# Altium schematic sheet SCH_Mayaptrung.SchDoc
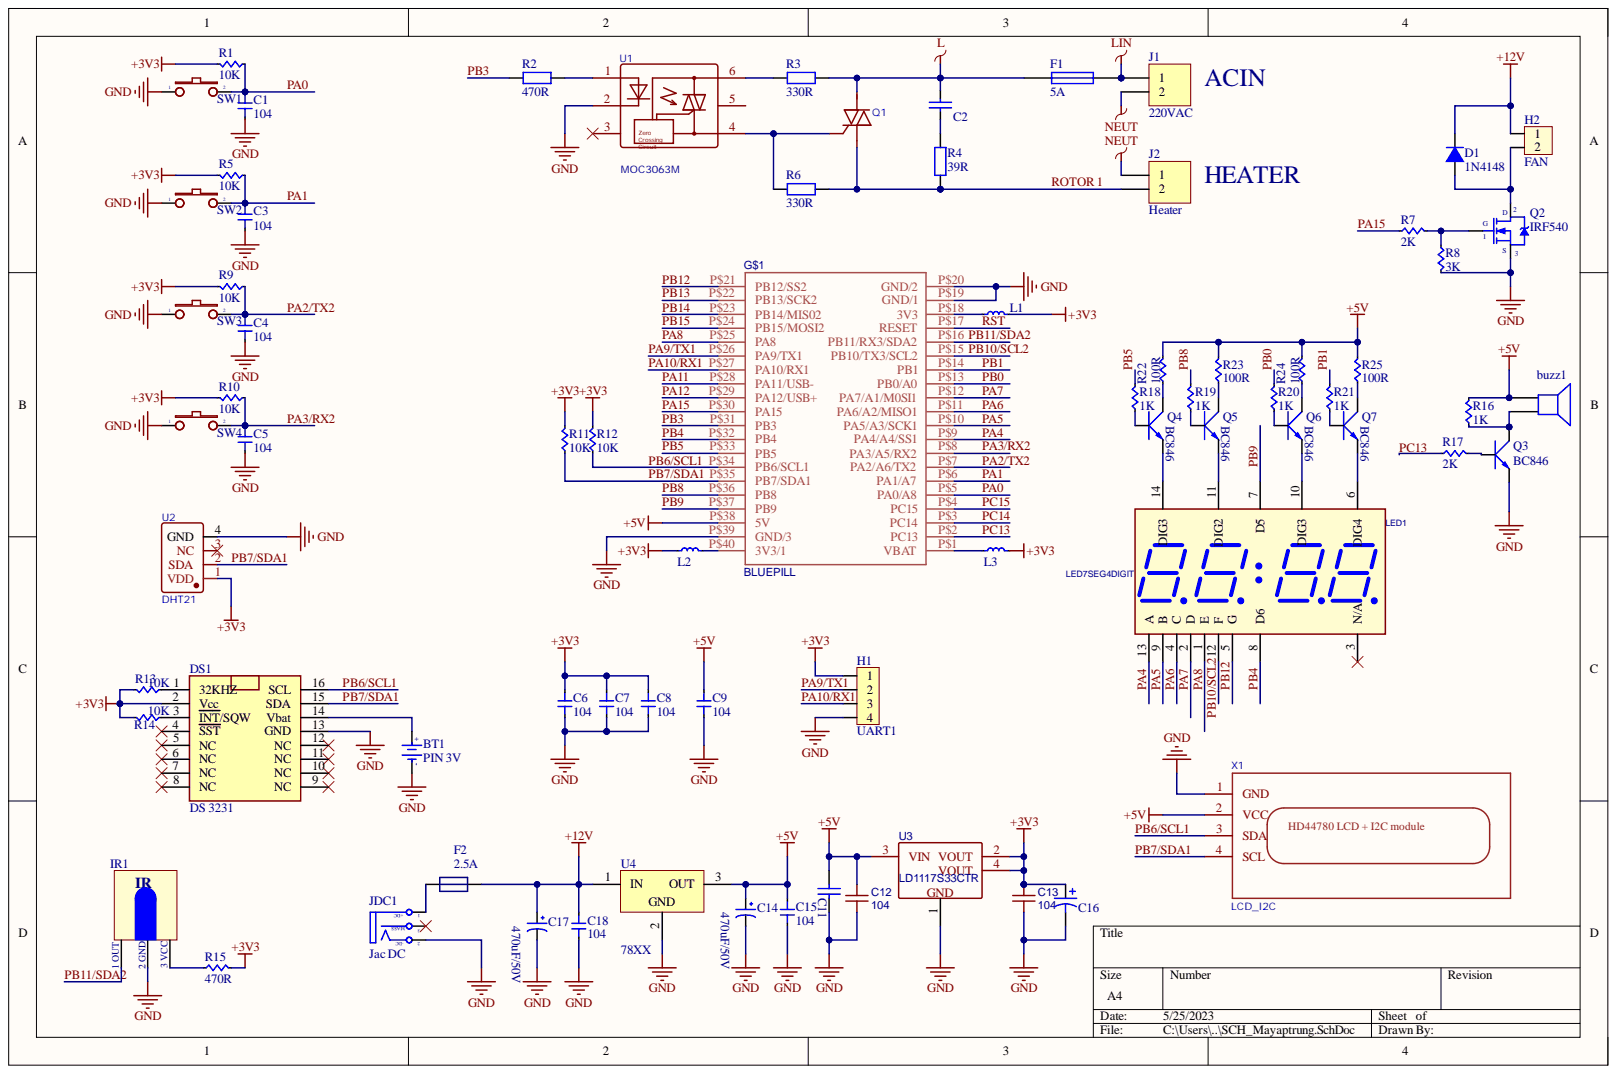
[diagram p0: SCH_Mayaptrung.SchDoc]

## Parts
BT1  PIN 3V  [PIN CR1220-SMD]
buzz1  Còi Chip 5V 9.5x12MM  [SPEAKER]  (value not drawn)
C1  104  [Cap 0805]
C2  104/630V  [TU FLIM P7.5 WIMA]  (value not drawn)
C3  104  [Cap 0805]
C4  104  [Cap 0805]
C5  104  [Cap 0805]
C6  104  [Cap 0805]
C7  104  [Cap 0805]
C8  104  [Cap 0805]
C9  104  [Cap 0805]
C11  100uF  [tu 1210 Dep]  (value not drawn)
C12  104  [Tu 0603]
C13  104  [Tu 0603]
C14  470uF/50V  [Tu hoa 8mm]
C15  104  [Cap 0805]
C16  470uF/16V  [CAP-SMD_BD6.3-L6.6-W6.6-FD]  (value not drawn)
C17  470uF/50V  [Tu hoa 8mm]
C18  104  [Cap 0805]
D1  1N4148  [Diode 1N4148]
DS1  DS 3231  [SO16L]
F1  5A  [Fuse15A]
F2  2.5A  [F1812]
G$1  BLUEPILL  [BLUEPILL]
H1  UART1  [XH4P-2.54mm]
H2  FAN  [Header_Duc Cong_2P 2.54 T]
IR1  IR1  [IR GP1UE26]
J1  220VAC  [KF3.81-2P-PL]
J2  Heater  [KF3.81-2P-PL]
JDC1  Jac DC  [DC-IN]
L1  LCF0603-1R8J-T  [L 0603]  (value not drawn)
L2  LCF0603-1R8J-T  [L 0603]  (value not drawn)
L3  LCF0603-1R8J-T  [L 0603]  (value not drawn)
LED1  LED7SEG4DIGIT  [LED 7 THANH  4 DIGIT 0.56]
Q1  BT136-800E_C2901010  [TO-252-3_L6.6-W6.1-P4.57-LS9.9-BR-CW]  (value not drawn)
Q2  IRF540  [TO220]
Q3  BC846  [SOT23BCE]
Q4  BC846  [SOT23BCE]
Q5  BC846  [SOT23BCE]
Q6  BC846  [SOT23BCE]
Q7  BC846  [SOT23BCE]
R1  10K  [Res 0805]
R2  470R  [tro smd 0805]
R3  330R  [tro smd 0805]
R4  39R  [tro smd 1206]
R5  10K  [Res 0805]
R6  330R  [tro smd 0805]
R7  2K  [Res 0805]
R8  3K  [Res 0805]
R9  10K  [Res 0805]
R10  10K  [Res 0805]
R11  10K  [Res 0805]
R12  10K  [Res 0805]
R13  10K  [Res 0805]
R14  10K  [Res 0805]
R15  470R  [Res 0805]
R16  1K  [Res 0805]
R17  2K  [Res 0805]
R18  1K  [Res 0805]
R19  1K  [Res 0805]
R20  1K  [Res 0805]
R21  1K  [Res 0805]
R22  100R  [Res 0805]
R23  100R  [Res 0805]
R24  100R  [Res 0805]
R25  100R  [Res 0805]
SW1  SW TACT 4PIN  [button 4.5x4.5x6 SMD]  (value not drawn)
SW2  SW TACT 4PIN  [button 4.5x4.5x6 SMD]  (value not drawn)
SW3  SW TACT 4PIN  [button 4.5x4.5x6 SMD]  (value not drawn)
SW4  SW TACT 4PIN  [button 4.5x4.5x6 SMD]  (value not drawn)
U1  MOC3063M  [DIP-6]
U2  DHT21  [SENSOR-TH_HAIGU_DHT21]
U3  LD1117S33CTR  [SOT-223-4_L6.5-W3.5-P2.30-LS7.0-BR]
U4  78XX  [TO252_NEW]
X1  LCD_I2C  [I2C LCD1602 I2C PCF8574AT]

## Nets
+3V3: C6.1 C7.1 C8.1 C13.2 C16.1 DS1.2(Vcc) H1.1 L1.2 L2.1 L3.2 R1.2 R5.2 R9.2 R10.2 R11.2 R12.2 R13.2 R14.2 R15.2 U2.1(VDD) U3.2(VOUT) U3.4(VOUT)
+5V: buzz1.1 C9.1 C11.1 C12.2 C14.1 C15.1 G$1.P$38(5V) R16.2 R22.2 R23.2 R24.2 R25.2 U3.3(VIN) U4.3 X1.2(VCC)
+12V: C17.1 C18.1 D1.2 F2.2 H2.1 U4.1
GND: BT1.2 C1.2 C3.2 C4.2 C5.2 C6.2 C7.2 C8.2 C9.2 C11.2 C12.1 C13.1 C14.2 C15.2 C16.2 C17.2 C18.2 DS1.13(GND) G$1.P$19(GND/1) G$1.P$20(GND/2) G$1.P$39(GND/3) H1.4 IR1.2 JDC1.2 Q2.3 Q3.3 R8.2 SW1.1 SW2.1 SW3.1 SW4.1 U1.2 U2.4(GND) U3.1 U4.2 X1.1(GND)
L: C2.2 F1.1 Q1.2 R3.2
LIN: F1.2 J1.1
NEUT: J1.2 J2.1
PA0: C1.1 G$1.P$5(PA0/A8) R1.1 SW1.2
PA1: C3.1 G$1.P$6(PA1/A7) R5.1 SW2.2
PA2/TX2: C4.1 G$1.P$7(PA2/A6/TX2) R9.1 SW3.2
PA3/RX2: C5.1 G$1.P$8(PA3/A5/RX2) R10.1 SW4.2
PA4: G$1.P$9(PA4/A4/SS1) LED1.13(A)
PA5: G$1.P$10(PA5/A3/SCK1) LED1.9(B)
PA6: G$1.P$11(PA6/A2/MISO1) LED1.4(C)
PA7: G$1.P$12(PA7/A1/M0SI1) LED1.2(D)
PA8: G$1.P$25(PA8) LED1.1(E)
PA9/TX1: G$1.P$26(PA9/TX1) H1.2
PA10/RX1: G$1.P$27(PA10/RX1) H1.3
PA11: G$1.P$28(PA11/USB-)
PA12: G$1.P$29(PA12/USB+)
PA15: G$1.P$30(PA15) R7.1
PB0: G$1.P$13(PB0/A0) R20.2
PB1: G$1.P$14(PB1) R21.2
PB3: G$1.P$31(PB3) R2.1
PB4: G$1.P$32(PB4) LED1.8(D6)
PB5: G$1.P$33(PB5) R18.2
PB6/SCL1: DS1.16(SCL) G$1.P$34(PB6/SCL1) R12.1 X1.3(SDA)
PB7/SDA1: DS1.15(SDA) G$1.P$35(PB7/SDA1) R11.1 U2.2(SDA) X1.4(SCL)
PB8: G$1.P$36(PB8) R19.2
PB9: G$1.P$37(PB9) LED1.7(D5)
PB10/SCL2: G$1.P$15(PB10/TX3/SCL2)
PB11/SDA2: G$1.P$16(PB11/RX3/SDA2) IR1.1
PB12: G$1.P$21(PB12/SS2) LED1.5(G)
PB13: G$1.P$22(PB13/SCK2)
PB14: G$1.P$23(PB14/MIS02)
PB15: G$1.P$24(PB15/MOSI2)
PC13: G$1.P$2(PC13) R17.1
PC14: G$1.P$3(PC14)
PC15: G$1.P$4(PC15)
ROTOR 1: J2.2 Q1.1 R4.1 R6.2
RST: G$1.P$17(RESET)
NetBT1_1: BT1.1 DS1.14(Vbat)
Netbuzz1_2: buzz1.2 Q3.2 R16.1
NetC2_1: C2.1 R4.2
NetD1_1: D1.1 H2.2 Q2.2
NetDS1_1: DS1.1(32KHZ) R13.1
NetDS1_3: DS1.3(INT/SQW) R14.1
NetF2_1: F2.1 JDC1.1
NetG$1_P$1: G$1.P$1(VBAT) L3.1
NetG$1_P$18: G$1.P$18(3V3) L1.1
NetG$1_P$40: G$1.P$40(3V3/1) L2.2
NetIR1_3: IR1.3 R15.1
NetLED1_6: LED1.6(DIG4) Q7.3
NetLED1_10: LED1.10(DIG3) Q6.3
NetLED1_11: LED1.11(DIG2) Q5.3
NetLED1_14: LED1.14(DIG3) Q4.3
NetQ1_3: Q1.3 R6.1 U1.4
NetQ2_1: Q2.1 R7.2 R8.1
NetQ3_1: Q3.1 R17.2
NetQ4_1: Q4.1 R18.1
NetQ4_2: Q4.2 R22.1
NetQ5_1: Q5.1 R19.1
NetQ5_2: Q5.2 R23.1
NetQ6_1: Q6.1 R20.1
NetQ6_2: Q6.2 R24.1
NetQ7_1: Q7.1 R21.1
NetQ7_2: Q7.2 R25.1
NetR2_2: R2.2 U1.1
NetR3_1: R3.1 U1.6

Not connected: DS1 4(SST) 5(NC) 6(NC) 7(NC) 8(NC) 9(NC) 10(NC) 11(NC) 12(NC); JDC1 3; LED1 3(N/A) 12(F); U1 3 5; U2 3(NC)

## Library data of the parts
BT1 = PIN 3V: Battery 3VDC; Component Kind: Standard; Library Name: THU VIEN SCH.SchLib; Value: PIN 3V
buzz1 = Coi Chip 5V: Cắm; Don_Vi: Con; Ma_Hang: MH000902; Chung_Loai: Cắm; Component Kind: Standard; Library Name: THU VIEN SCH.SchLib; Ten_Linh_Kien: Còi Chip 5V 9.5x12MM
C1 C3-C9 C15 C18 = Cap SMD: C49678; Component Kind: Standard; Library Name: Thu_vien2.SCHLIB
C2 = TU FLIM: C109513; Chung_Loai: Dán; Don_Vi: Con; Ma_Hang: MH004560; Ten_linh_Kien: Tụ 5 104J100; Component Kind: Standard; Library Name: THU VIEN SCH.SchLib
C11 = tu 1210: Cắm; Don_Vi: Con; Ma_Hang: MH003965; So_Chan: 2; Ten_Linh_Kien: Tụ tantalum 473-47nF 50V 20% DIP5.08 (sứ vàng-10PCS); Chung_Loai: Cắm; Component Kind: Standard; Library Name: THU VIEN SCH.SchLib
C12 C13 = C 0603: C14663; BOM_Manufacturer: Murata Electronics; BOM_Manufacturer Part: GRM1885C1H1R5CA01D; Contributor: LCSC; nameAlias: Capacitance; BOM_Supplier: LCSC; BOM_Supplier Part: C143017; Component Kind: Standard; Library Name: Thu_vien2.SCHLIB; spicePre: C; spiceSymbolName: GRM1885C1H1R5CA01D
C14 C17 = Tu 8x10: Cắm; Don_Vi: Con; Ma_Hang: MH006864; So_Chan: 2; Ten_Linh_Kien: Tụ Hóa 220uF 25V 8x10MM; Chung_Loai: Cắm; Component Kind: Standard; Library Name: Thu_vien2.SCHLIB
C16 = cap 8x16 L: Component Kind: Standard; Library Name: Thu_vien2.SCHLIB
D1 = Diode 1N4148: Cắm; Don_Vi: Con; Ma_Hang: MH001065; Ten_Linh_Kien: Diode 1N4148 DO35 450mA 100V ( 1N4148 DIP); Chung_Loai: Cắm; Component Kind: Standard; Library Name: THU VIEN SCH.SchLib
DS1 = DS 3231: Dán; Don_Vi: Con; Ma_Hang: MH002604; Ten_Linh_Kien: DS3231N SOIC16; Chung_Loai: Dán; Component Kind: Standard; Library Name: THU VIEN SCH.SchLib
F1 = FUSE CAM: Cắm; Component Kind: Standard; Library Name: THU VIEN SCH.SchLib
F2 = FUSE JK250 120mA: C78695; Manufacturer: Electronic Alliance; Manufacturer Part Number: JK250-120U; Component Kind: Standard; Library Name: Thu_vien2.SCHLIB; Supplier 1: KME; Supplier Part Number 1: JK250-120U
G$1 = BLUEPILL: Contributor: Eric LAVOIE; Component Kind: Standard; Library Name: Thu_vien2.SCHLIB; spicePre: G; spiceSymbolName: BLUEPILL
H1 = Header 4P: Cắm; Chung_Loai: Cắm; Component Kind: Standard; Library Name: THU VIEN SCH.SchLib
H2 = Header 2P: Cắm; Chung_Loai: Cắm; Component Kind: Standard; Library Name: THU VIEN SCH.SchLib
IR1 = IR1: Receiver Infraed IC; Component Kind: Standard; Library Name: THU VIEN SCH.SchLib
J1 J2 = Header 2: Header, 2-Pin; Component Kind: Standard; LatestRevisionDate: 17-Jul-2002; LatestRevisionNote: Re-released for DXP Platform.; Library Name: Lib Prj sim800C.SchLib; Publisher: Altium Limited
JDC1 = DCIN: Jac DC input; Component Kind: Standard; Library Name: THU VIEN SCH.SchLib; Value: Jac DC
L1-L3 = L SMD: C251961 extend; Manufacturer: Murata Electronics North America; Manufacturer Part Number: BLM15AG102SN1D; Current Rating (Max): 300mA; DC Resistance (DCR) (Max): 650 mOhm; Impedance @ Frequency: 1 kOhm @ 100MHz; Number of Lines: 1; Category: Filters; Component Kind: Standard; ComponentLink1Description: http://search.murata.co.jp/Ceramy/image/img/PDF/ENG/L0110S0100BLM15AG_SN.pdf; ComponentLink1URL: http://search.murata.co.jp/Ceramy/image/img/PDF/ENG/L0110S0100BLM15AG_SN.pdf; Filter Type: -; Height (Max): 0.022" (0.55mm); Library Name: Thu_vien2.SCHLIB; Mounting Type: Surface Mount; Operating Temperature: -55°C ~ 125°C; Package / Case: 0402 (1005 Metric); Packaging: Cut Tape (CT); Part Status: Active; Pricing 1: 1=0.1, 10=0.084, 50=0.0688, 100=0.0505, 250=0.03668, 500=0.03364, 1000=0.02905, 5000=0.02294 (USD); Ratings: -; RoHS: RoHS Compliant; Size / Dimension: 0.039" L x 0.020" W (1.00mm x 0.50mm); Stock 1: 162881; Supplier 1: Digi-Key; Supplier Part Number 1: 490-1007-1-ND
LED1 = LED 7SEG 4 CLOCK: Component Kind: Standard; Library Name: Led 7SEG.SchLib
Q2 = MOSFET_N: Component Kind: Standard; Library Name: THU VIEN SCH.SchLib
Q3 = TRANS NPN: MMBTA42: 300V-NPN; Component Kind: Standard; Library Name: THU VIEN SCH.SchLib
Q4-Q7 = TRANS NPN: PN222A; MMBTA42: 300V-NPN; Component Kind: Standard; Library Name: THU VIEN SCH.SchLib
R1 R5 R9-R14 = Res SMD: C17414; Component Kind: Standard; Library Name: Thu_vien2.SCHLIB
R2 R3 R6 = Tro 0805 1% 0.22R: Dán; Don_Vi: Con; Ma_Hang: MH005466; So_Chan: 2; Ten_Linh_Kien: Trở 0805 1% 0.22R; Chung_Loai: Dán; Component Kind: Standard; Library Name: Thu_vien2.SCHLIB
R4 = Tro 1206 1% 0.68R: Dán; Don_Vi: Con; Ma_Hang: MH008104; So_Chan: 2; Ten_linh_Kien: Trở 1206 1% 0.68R; Chung_Loai: Dán; Component Kind: Standard; Library Name: THU VIEN SCH.SchLib
R7 R16-R25 = Res SMD: C17604; Component Kind: Standard; Library Name: Thu_vien2.SCHLIB
R8 = Res SMD: C17661; Component Kind: Standard; Library Name: Thu_vien2.SCHLIB
R15 = Res SMD: C17710; Component Kind: Standard; Library Name: Thu_vien2.SCHLIB
SW1-SW4 = SW TACT 4PIN MICRO SMD 4x4x5MM: Manufacturer: CHINA; Manufacturer Part Number: Switch Tact 4 Pin Micro 4x4x5MM; Component Kind: Standard; Description: SW TACT 4PIN MICRO SMD 4x4x5MM; Library Name: Thu_vien2.SCHLIB; Supplier 1: MINH HA; Supplier Part Number 1: MH010034
U3 = LD1117S33CTR: BOM_Manufacturer: STMicroelectronics; SMT Type: Extend; BOM_LCSC Assembly: Yes; BOM_Manufacturer Part: LD1117S33CTR; BOM_Supplier: LCSC; BOM_Supplier Part: C35879; Component Kind: Standard; image: //image.lceda.cn/szlcsc/C35879.jpg; Library Name: Thu_vien2.SCHLIB; spicePre: U; spiceSymbolName: LD1117S33CTR
U4 = 78M05: Dán; Don_Vi: Con; Ma_Hang: MH001917; Ten_Linh_Kien: 78M05 TO252; Chung_Loai: Dán; Component Kind: Standard; Library Name: THU VIEN SCH.SchLib
Q1 = BT136-800E_C2901010: Component Kind: Standard; Library Name: Thu_vien2.SCHLIB
U1 = MOC3063M: Component Kind: Standard; Library Name: Thu_vien2.SCHLIB
U2 = DHT21: Component Kind: Standard; Library Name: Thu_vien2.SCHLIB
X1 = LCD_I2C: Component Kind: Standard; Library Name: Thu_vien2.SCHLIB
